# Altium schematic sheet baseboard.SchDoc
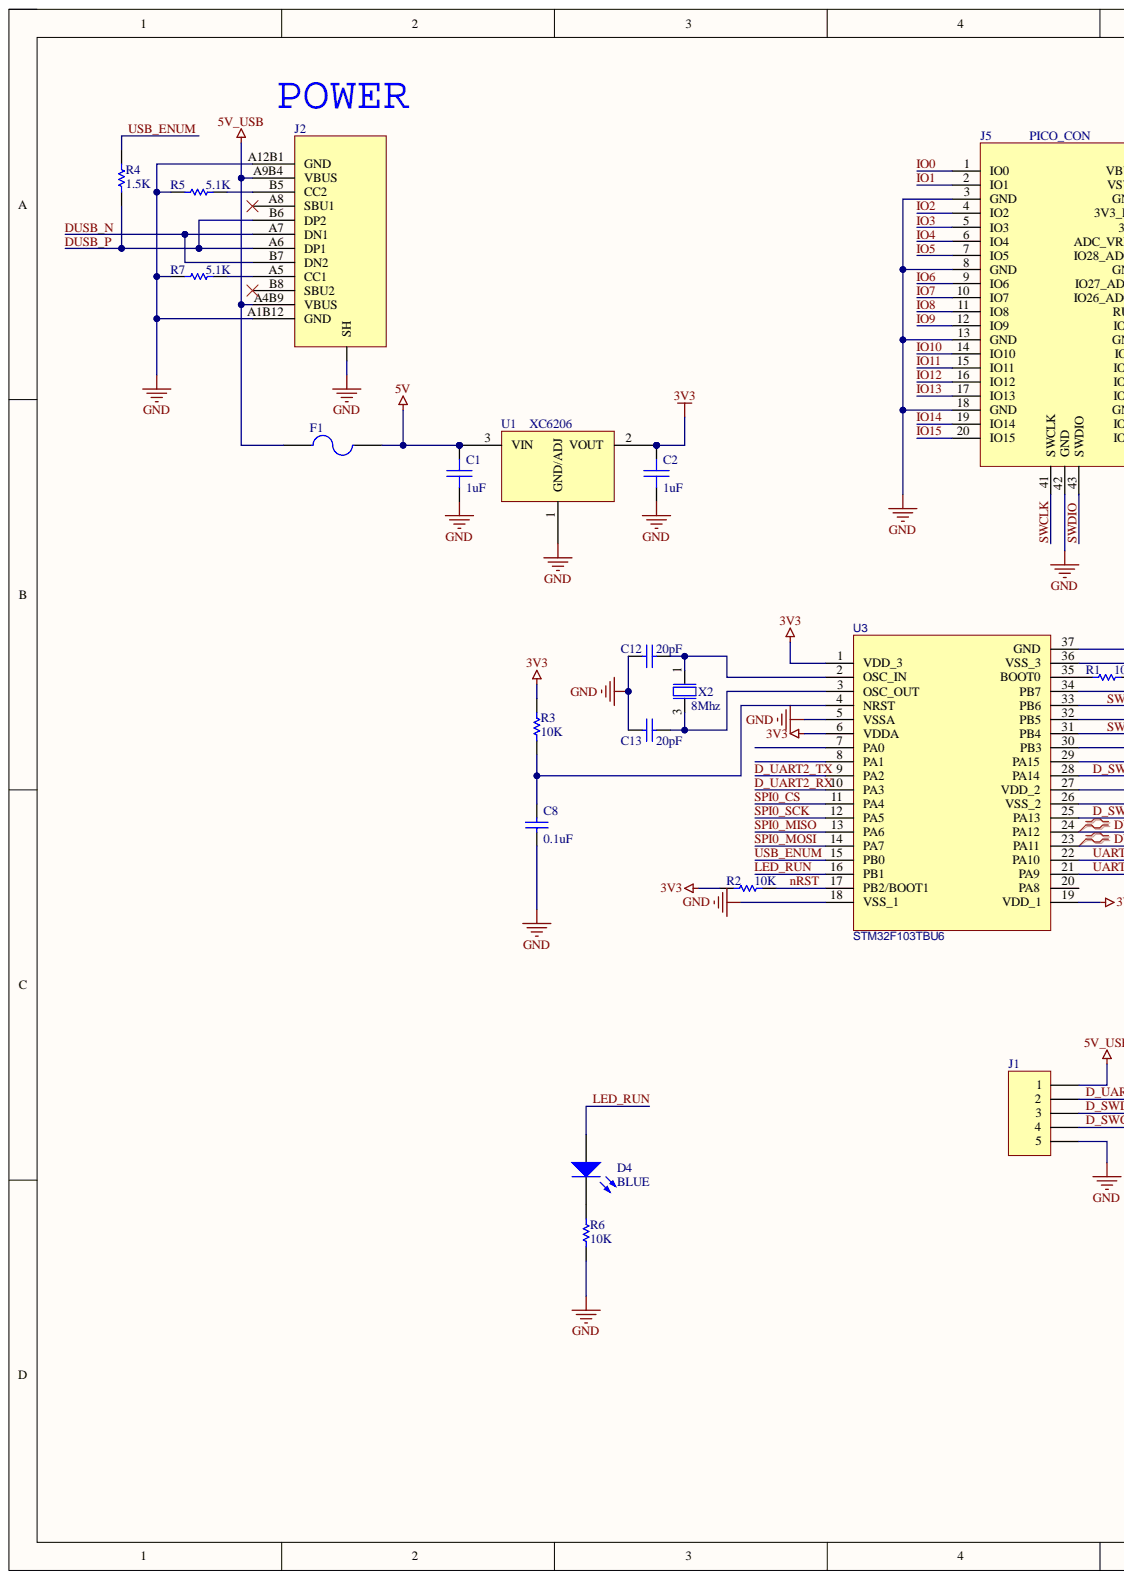
[diagram p0: baseboard.SchDoc, left]
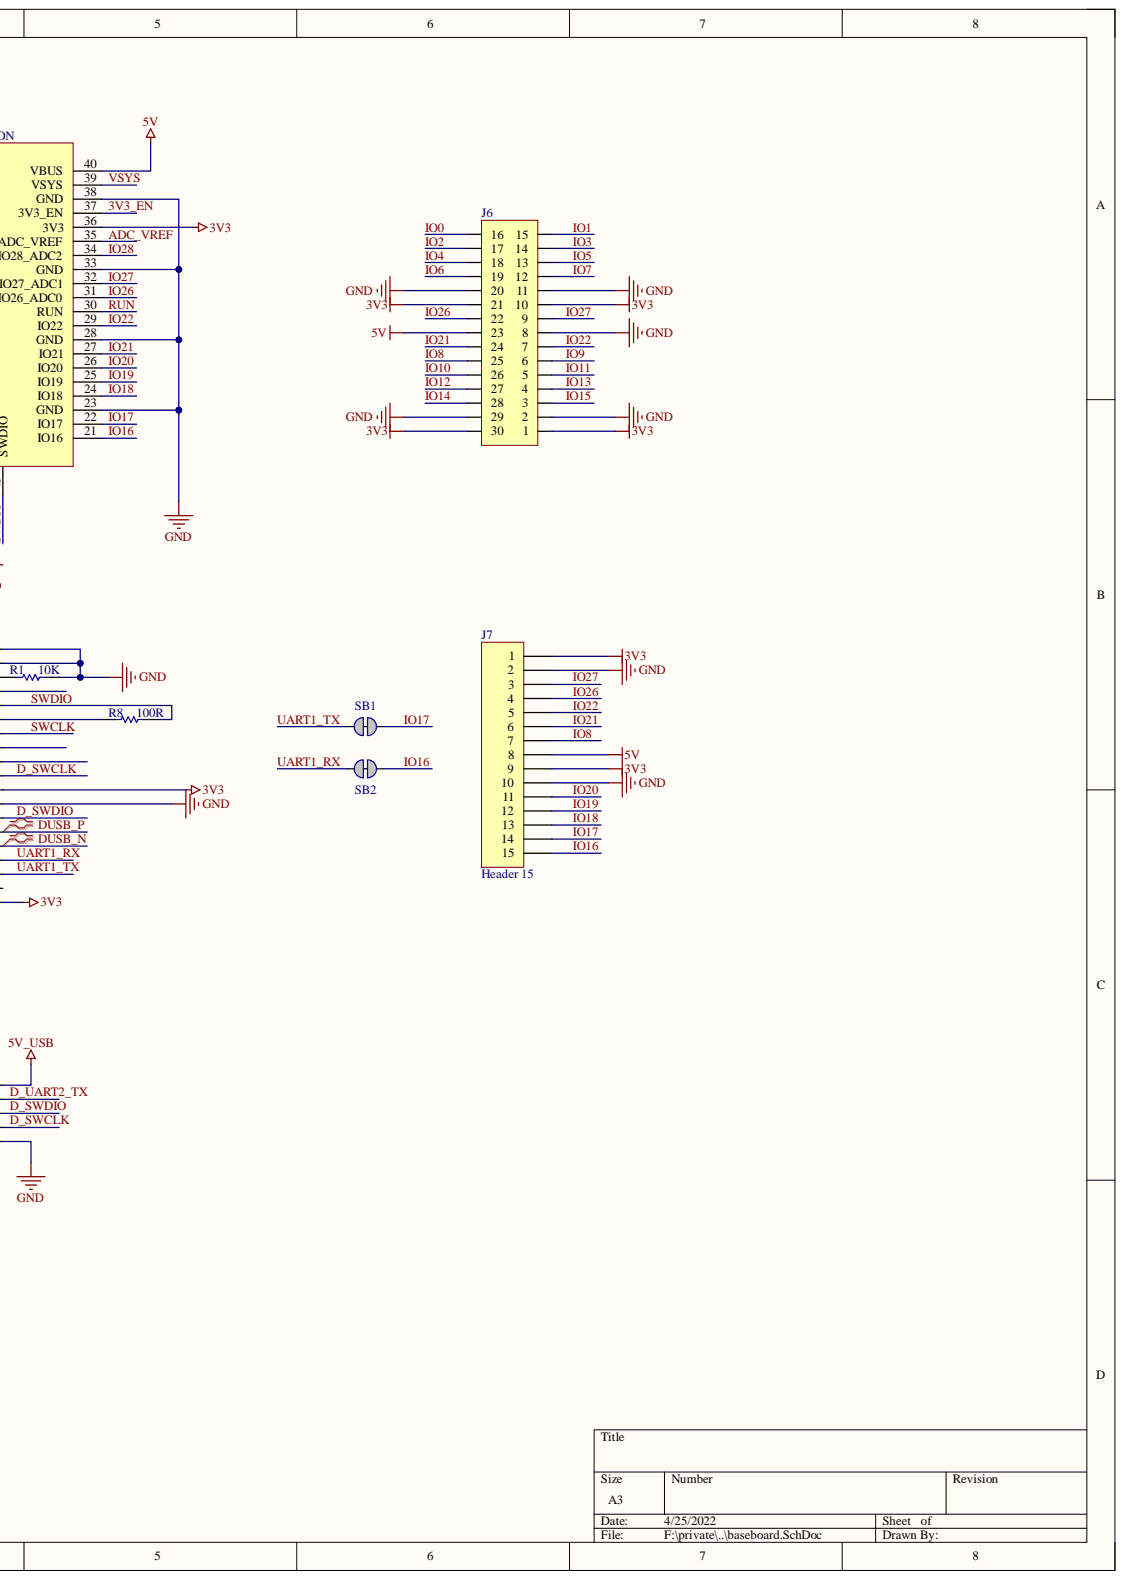
[diagram p1: baseboard.SchDoc, right]

## Parts
C1  1uF  [0603_C]
C2  1uF  [0603_C]
C8  value 0.1uF  [0603_C]  (hidden comment: C0603)
C12  value 20pF  [0603_C]  (hidden comment: C0603)
C13  value 20pF  [0603_C]  (hidden comment: C0603)
D4  BLUE  [0603_LED]
F1  Fuse 2  [FU 0805]  (value not drawn)
J1  (no value)  [HEADER_1.27_SMT_5x1]
J2  Type_C_16P  [Type_C_16P]  (value not drawn)
J5  PICO_CON  [PICO_CONN]
J6  Header 30  [HEADER_2x15]  (value not drawn)
J7  Header 15  [HEADER_1x15]
R1  value 10K  [0603_R]  (hidden comment: R0603)
R2  value 10K  [0603_R]  (hidden comment: R0603)
R3  value 10K  [0603_R]  (hidden comment: R0603)
R4  value 1.5K  [0603_R]  (hidden comment: R0603)
R5  value 5.1K  [0603_R]  (hidden comment: R0603)
R6  value 10K  [0603_R]  (hidden comment: R0603)
R7  value 5.1K  [0603_R]  (hidden comment: R0603)
R8  value 100R  [0603_R]  (hidden comment: R0603)
SB1  Solderbridge  [Solderbridge_Shorted]  (value not drawn)
SB2  Solderbridge  [Solderbridge_Shorted]  (value not drawn)
U1  XC6206  [SOT23-3M]
U3  STM32F103TBU6  [*QFN50P600X600X100-37N]
X2  8Mhz  [BT-XTAL_3225]

## Nets
3V3: C2.1 J5.36(3V3) J6.1 J6.10 J6.21 J6.30 J7.1 J7.9 R2.1 R3.1 U1.2 U3.1(VDD_3) U3.6(VDDA) U3.19(VDD_1) U3.27(VDD_2)
5V: C1.1 F1.2 J5.40(VBUS) J6.23 J7.8 U1.3
5V_USB: F1.1 J1.1 J2.A4B9(VBUS) J2.A9B4(VBUS)
GND: C1.2 C2.2 C8.1 C12.2 C13.2 J1.5 J2.0(SH) J2.A1B12(GND) J2.A12B1(GND) J5.3(GND) J5.8(GND) J5.13(GND) J5.18(GND) J5.23(GND) J5.28(GND) J5.33(GND) J5.38(GND) J5.42(GND) J6.2 J6.8 J6.11 J6.20 J6.29 J7.2 J7.10 R1.2 R5.1 R6.1 R7.1 U1.1 U3.5(VSSA) U3.18(VSS_1) U3.26(VSS_2) U3.36(VSS_3) U3.37(GND) X2.2 X2.4
3V3_EN: J5.37(3V3_EN)
ADC_VREF: J5.35(ADC_VREF)
D_SWCLK: J1.4 U3.28(PA14)
D_SWDIO: J1.3 U3.25(PA13)
D_UART2_RX: U3.10(PA3)
D_UART2_TX: J1.2 U3.9(PA2)
DUSB_N: J2.A7(DN1) J2.B7(DN2) U3.23(PA11)
DUSB_P: J2.A6(DP1) J2.B6(DP2) R4.2 U3.24(PA12)
IO0: J5.1(IO0) J6.16
IO1: J5.2(IO1) J6.15
IO2: J5.4(IO2) J6.17
IO3: J5.5(IO3) J6.14
IO4: J5.6(IO4) J6.18
IO5: J5.7(IO5) J6.13
IO6: J5.9(IO6) J6.19
IO7: J5.10(IO7) J6.12
IO8: J5.11(IO8) J6.25 J7.7
IO9: J5.12(IO9) J6.6
IO10: J5.14(IO10) J6.26
IO11: J5.15(IO11) J6.5
IO12: J5.16(IO12) J6.27
IO13: J5.17(IO13) J6.4
IO14: J5.19(IO14) J6.28
IO15: J5.20(IO15) J6.3
IO16: J5.21(IO16) J7.15 SB2.1
IO17: J5.22(IO17) J7.14 SB1.1
IO18: J5.24(IO18) J7.13
IO19: J5.25(IO19) J7.12
IO20: J5.26(IO20) J7.11
IO21: J5.27(IO21) J6.24 J7.6
IO22: J5.29(IO22) J6.7 J7.5
IO26: J5.31(IO26_ADC0) J6.22 J7.4
IO27: J5.32(IO27_ADC1) J6.9 J7.3
IO28: J5.34(IO28_ADC2)
LED_RUN: D4.1 U3.16(PB1)
nRST: R2.2 U3.17(PB2/BOOT1)
RUN: J5.30(RUN)
SPI0_CS: U3.11(PA4)
SPI0_MISO: U3.13(PA6)
SPI0_MOSI: U3.14(PA7)
SPI0_SCK: U3.12(PA5)
SWCLK: J5.41(SWCLK) U3.31(PB4)
SWDIO: J5.43(SWDIO) R8.2 U3.33(PB6)
UART1_RX: SB2.2 U3.22(PA10)
UART1_TX: SB1.2 U3.21(PA9)
USB_ENUM: R4.1 U3.15(PB0)
VSYS: J5.39(VSYS)
NetC8_2: C8.2 R3.2 U3.4(NRST)
NetC12_1: C12.1 U3.2(OSC_IN) X2.1
NetC13_1: C13.1 U3.3(OSC_OUT) X2.3
NetD4_2: D4.2 R6.2
NetJ2_A5: J2.A5(CC1) R7.2
NetJ2_B5: J2.B5(CC2) R5.2
NetR1_1: R1.1 U3.35(BOOT0)
NetR8_1: R8.1 U3.32(PB5)

Not connected: J2 A8(SBU1) B8(SBU2); U3 7(PA0) 8(PA1) 20(PA8) 29(PA15) 30(PB3) 34(PB7)

## Library data of the parts
C1 C2 = SMT_C_0402: Surface mount capacitor 0402; Component Kind: Standard; Library Name: Vowstar_Basic_Device.IntLib
C8 C12 C13 = C0603: Capacitor; Component Kind: Standard; LatestRevisionDate: 17-Jul-2002; LatestRevisionNote: Re-released for DXP Platform.; Library Name: MYLIB.IntLib; PackageDescription: Radial Cap, Thru-Hole; 2 Leads; 0.3 in Pin Spacing; PackageReference: RAD-0.3; Published: 8-Jun-2000; Signal Integrity: Cap; Simulation: CAP; Publisher: Altium Limited
D4 = D0603: Typical RED, GREEN, YELLOW, AMBER GaAs LED; Component Kind: Standard; LatestRevisionDate: 17-Jul-2002; LatestRevisionNote: Re-released for DXP Platform.; Library Name: MYLIB.IntLib; PackageDescription: SM LED; 2 Flat Leads; PackageReference: 3.2X1.6X1.1; PackageVersion: Feb-2002; Published: 8-Jun-2000; Simulation: LED2; Publisher: Altium Limited
F1 = Fuse 2: Fuse; Component Kind: Standard; LatestRevisionDate: 17-Jul-2002; LatestRevisionNote: Re-released for DXP Platform.; Library Name: Miscellaneous Devices.IntLib; PackageDescription: Thru-Hole; 2 Leads; PackageReference: PIN-W2/E2.8; Published: 8-Jun-2000; Simulation: FUSE; Publisher: Altium Limited
J1 = Header_5: Header, 4-Pin; Component Kind: Standard; LatestRevisionDate: 17-Jul-2002; LatestRevisionNote: Re-released for DXP Platform.; Signal Integrity: Connector; Library Name: ICESugar-Pro.SCHLIB; Publisher: Altium Limited
J6 = Header 2x15: Header, 30-Pin; Component Kind: Standard; LatestRevisionDate: 17-Jul-2002; LatestRevisionNote: Re-released for DXP Platform.; Library Name: i5_v6.0.SCHLIB; Signal Integrity: Connector; Publisher: Altium Limited
R1-R8 = R0603: Resistor; Component Kind: Standard; LatestRevisionDate: 17-Jul-2002; LatestRevisionNote: Re-released for DXP Platform.; Library Name: MYLIB.IntLib; PackageDescription: Axial Device, Thru-Hole; 2 Leads; 0.3 in Pin Spacing; PackageReference: AXIAL-0.3; Published: 8-Jun-2000; Signal Integrity: Res; Simulation: RESISTOR; Publisher: Altium Limited
SB1 SB2 = Solderbridge Shorted: Shorted Solderbridge; Artikkelnr Noca: N.A.; Database Table Name: Connectors$; Artikkelnr Simpro: N.A.; Component Kind: Net Tie; Footprint Doc: Solderbridge_Shorted; LatestRevisionDate: 2012-08-07; Library Name: Nordic Passive DB.DbLib; Part Number: Solderbridge Shorted; Pin Count: 2; Published: 2012-08-07; Value: Solderbridge; Publisher: Nordic Semiconductor
U1 = NCP1117: NCP1117, NCV1117. 1.0 A Low-Dropout Positive  Fixed and Adjustable  Voltage Regulators; Component Kind: Standard; Library Name: Vowstar_IC_General.IntLib
U3 = STM32F103T8U6: BOM_Manufacturer: STMicroelectronics; BOM_Manufacturer Part: STM32F103T8U6; BOM_Supplier: LCSC; BOM_Supplier Part: C19671; Component Kind: Standard; image: //image.lceda.cn/szlcsc/C19671.jpg; Library Name: teensy.SCHLIB; spicePre: U
X2 = XTAL SMD 12MHz (3225): XTAL SMD 3225, 8MHz, 18pF, ±30ppm; 2nd source part number: 184-2056; Manufacturer: TXC Corporation; Manufacturer Part Number: 7M-12.000MAAJ-T; Artikkelnr Noca: 22019166; Artikkelnr Simpro: N.A.; Database Table Name: Crystal_Osc$; Note: XTAL SMD 12.000MHz; 2nd source Supplier: Farnell; Component Kind: Standard; Datasheet: http://www.txccrystal.com/images/pdf/7m.pdf; Footprint Doc: BT-XTAL_3225; LatestRevisionDate: 2010-08-31; Library Name: Nordic Discrete DB.DbLib; Part Number: XTAL SMD 12MHz (3225); Pin Count: 4; Published: 2010-08-31; Supplier: Digi-Key; Supplier part number: 887-1121-1-ND; Value: 8Mhz; Publisher: Nordic Semiconductor
J2 = Type_C_16P: Component Kind: Standard; Library Name: ICESugar.SCHLIB
J5 = PICO_CON: Component Kind: Standard; Library Name: baseboard.SCHLIB
J7 = Header 15: Component Kind: Standard; Library Name: baseboard.SCHLIB
